# Altium schematic sheet Weapon_of_Aphelios.SchDoc
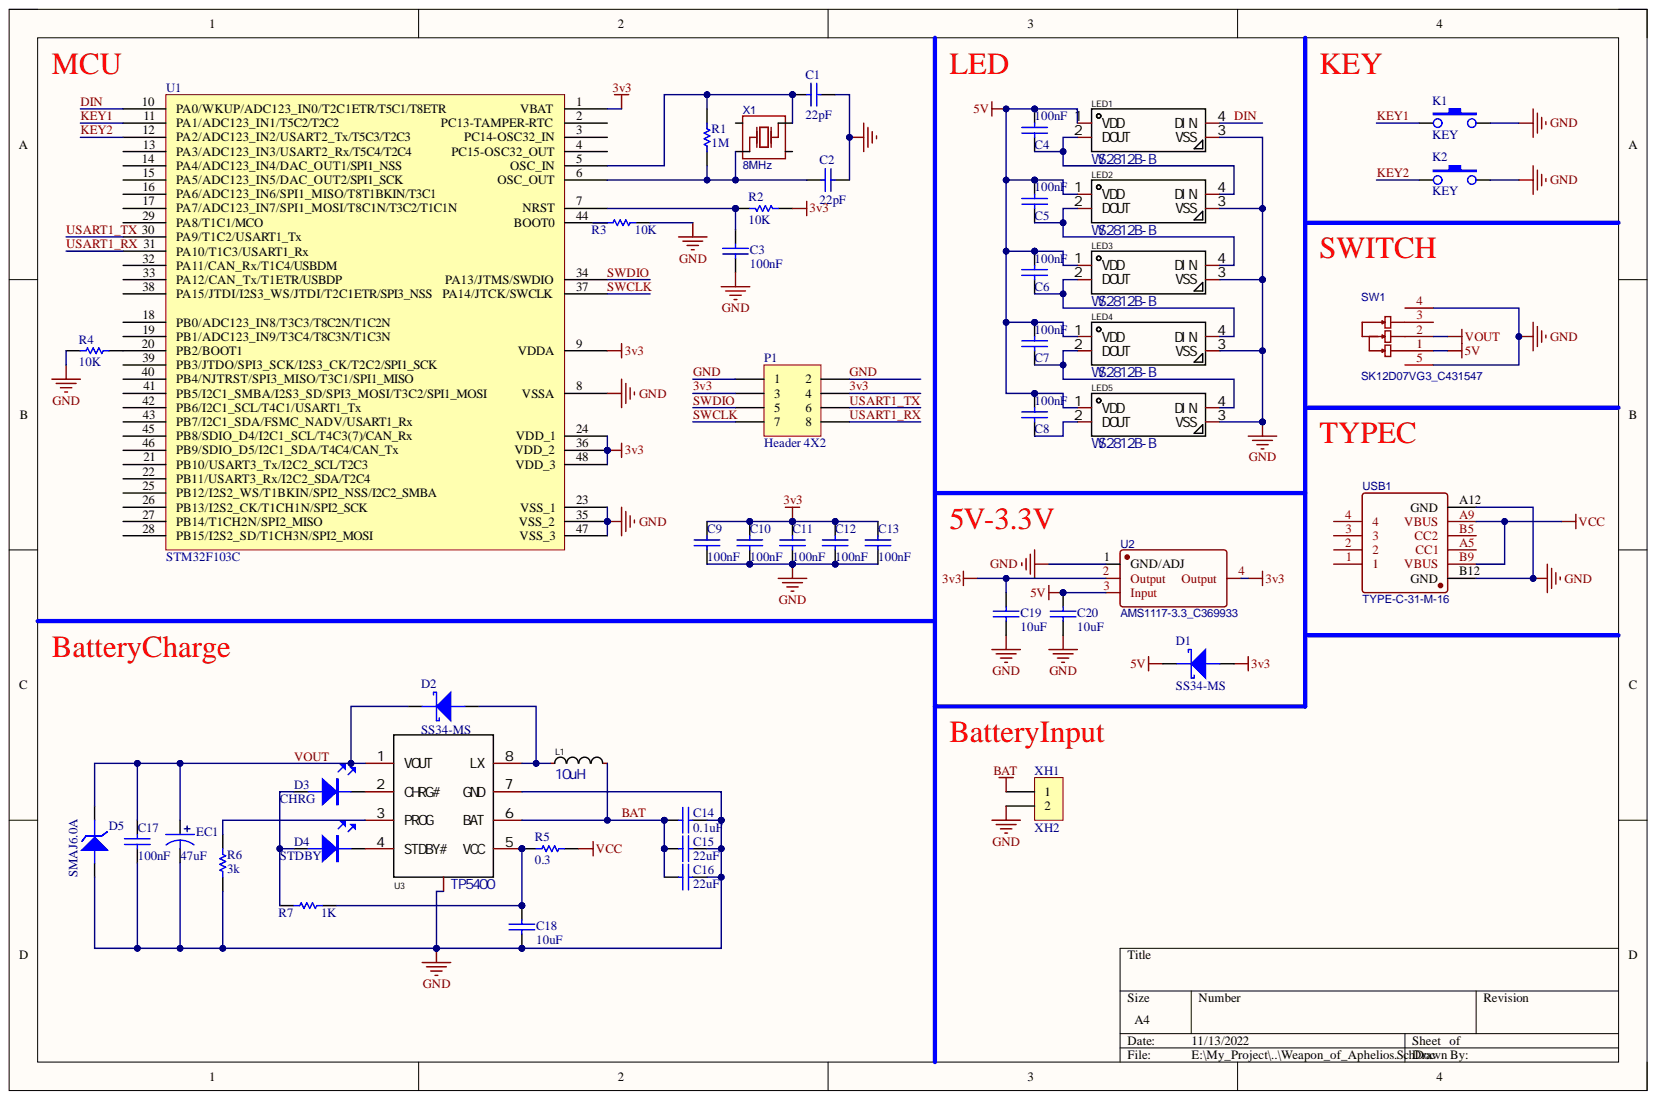
[diagram p0: Weapon_of_Aphelios.SchDoc]

## Parts
C1  22pF  [0603C]
C2  22pF  [0603C]
C3  100nF  [0603C]
C4  100nF  [0603C]
C5  100nF  [0603C]
C6  100nF  [0603C]
C7  100nF  [0603C]
C8  100nF  [0603C]
C9  100nF  [0603C]
C10  100nF  [0603C]
C11  100nF  [0603C]
C12  100nF  [0603C]
C13  100nF  [0603C]
C14  0.1uF  [0603C]
C15  22uF  [0603C]
C16  22uF  [0603C]
C17  100nF  [0603C]
C18  10uF  [0603C]
C19  10uF  [0603C]
C20  10uF  [0603C]
D1  SS34-MS  [SMA/DO-214AC]
D2  SS34-MS  [SMA/DO-214AC]
D3  CHRG  [LED-0603B]
D4  STDBY  [LED-0603B]
D5  SMAJ6.0A  [SMA/DO-214AC]
EC1  47uF  [SMD-EC5.0X5.3X5.4]
K1  KEY  [SMD-6X6X6]
K2  KEY  [SMD-6X6X6]
L1  10uH  [IND-SMD_L4.5-W4.1_TMPA0402SP]
LED1  WS2812B-B  [LED-SMD_4P-L5.0-W5.0-TL_WS2812B-B]
LED2  WS2812B-B  [LED-SMD_4P-L5.0-W5.0-TL_WS2812B-B]
LED3  WS2812B-B  [LED-SMD_4P-L5.0-W5.0-TL_WS2812B-B]
LED4  WS2812B-B  [LED-SMD_4P-L5.0-W5.0-TL_WS2812B-B]
LED5  WS2812B-B  [LED-SMD_4P-L5.0-W5.0-TL_WS2812B-B]
P1  Header 4X2  [HDR2X4]
R1  1M  [0603R]
R2  10K  [0603R]
R3  10K  [0603R]
R4  10K  [0603R]
R5  0.3  [0603R]
R6  3k  [0603R]
R7  1K  [0603R]
SW1  SK12D07VG3_C431547  [SW-TH_SK12D07VG3]
U1  STM32F103C  [LQFP-48_M]
U2  AMS1117-3.3_C369933  [SOT-223-4_L6.5-W3.5-P2.30-LS7.0-BR]
U3  TP5400  [SOIC-8_L5.0-W4.0-P1.27-LS6.0-BL-EP2.0]
USB1  TYPE-C-31-M-16  [TYPE-C-31-M-16]
X1  8MHz  [OSC-SMD_4P-L3.2-W2.5-BL]
XH1  XH2  [XH2/2.54mm/90]

## Nets
5V: C4.2 C5.2 C6.2 C7.2 C8.2 C20.2 D1.K LED1.1(VDD) LED2.1(VDD) LED3.1(VDD) LED4.1(VDD) LED5.1(VDD) SW1.1 U2.3(Input)
VCC: R5.1 USB1.A9(VBUS) USB1.B9(VBUS)
3v3: C9.2 C10.2 C11.2 C12.2 C13.2 C19.2 D1.A P1.3 P1.4 R2.1 U1.1(VBAT) U1.9(VDDA) U1.24(VDD_1) U1.36(VDD_2) U1.48(VDD_3) U2.2(Output) U2.4(Output)
BAT: C14.1 C15.1 C16.1 L1.2 U3.6(BAT) XH1.1
DIN: LED1.4(DIN) U1.10(PA0/WKUP/ADC123_IN0/T2C1ETR/T5C1/T8ETR)
GND: C1.1 C2.1 C3.2 C9.1 C10.1 C11.1 C12.1 C13.1 C14.2 C15.2 C16.2 C17.1 C18.1 C19.1 C20.1 D5.A EC1.2 K1.3 K2.3 LED1.3(VSS) LED2.3(VSS) LED3.3(VSS) LED4.3(VSS) LED5.3(VSS) P1.1 P1.2 R3.1 R4.1 R6.2 SW1.4 SW1.5 U1.8(VSSA) U1.23(VSS_1) U1.35(VSS_2) U1.47(VSS_3) U2.1(GND/ADJ) U3.7(GND) U3.9 USB1.A12(GND) USB1.B12(GND) XH1.2
KEY1: K1.1 U1.11(PA1/ADC123_IN1/T5C2/T2C2)
KEY2: K2.1 U1.12(PA2/ADC123_IN2/USART2_Tx/T5C3/T2C3)
SWCLK: P1.7 U1.37(PA14/JTCK/SWCLK)
SWDIO: P1.5 U1.34(PA13/JTMS/SWDIO)
USART1_RX: P1.8 U1.31(PA10/T1C3/USART1_Rx)
USART1_TX: P1.6 U1.30(PA9/T1C2/USART1_Tx)
VOUT: C17.2 D2.K D5.K EC1.1 SW1.2 U3.1(VOUT)
NetC1_2: C1.2 R1.1 U1.5(OSC_IN) X1.3
NetC2_2: C2.2 R1.2 U1.6(OSC_OUT) X1.1
NetC3_1: C3.1 R2.2 U1.7(NRST)
NetC4_1: C4.1 LED1.2(DOUT) LED2.4(DIN)
NetC5_1: C5.1 LED2.2(DOUT) LED3.4(DIN)
NetC6_1: C6.1 LED3.2(DOUT) LED4.4(DIN)
NetC7_1: C7.1 LED4.2(DOUT) LED5.4(DIN)
NetC8_1: C8.1 LED5.2(DOUT)
NetC18_2: C18.2 R5.2 R7.1 U3.5(VCC)
NetD2_A: D2.A L1.1 U3.8(LX)
NetD3_A: D3.A D4.A R7.2
NetD3_K: D3.K U3.2(CHRG#)
NetD4_K: D4.K U3.4(STDBY#)
NetR3_2: R3.2 U1.44(BOOT0)
NetR4_2: R4.2 U1.20(PB2/BOOT1)
NetR6_1: R6.1 U3.3(PROG)

Not connected: SW1 3; U1 2(PC13-TAMPER-RTC) 3(PC14-OSC32_IN) 4(PC15-OSC32_OUT) 13(PA3/ADC123_IN3/USART2_Rx/T5C4/T2C4) 14(PA4/ADC123_IN4/DAC_OUT1/SPI1_NSS) 15(PA5/ADC123_IN5/DAC_OUT2/SPI1_SCK) 16(PA6/ADC123_IN6/SPI1_MISO/T8T1BKIN/T3C1) 17(PA7/ADC123_IN7/SPI1_MOSI/T8C1N/T3C2/T1C1N) 18(PB0/ADC123_IN8/T3C3/T8C2N/T1C2N) 19(PB1/ADC123_IN9/T3C4/T8C3N/T1C3N) 21(PB10/USART3_Tx/I2C2_SCL/T2C3) 22(PB11/USART3_Rx/I2C2_SDA/T2C4) 25(PB12/I2S2_WS/T1BKIN/SPI2_NSS/I2C2_SMBA) 26(PB13/I2S2_CK/T1CH1N/SPI2_SCK) 27(PB14/T1CH2N/SPI2_MISO) 28(PB15/I2S2_SD/T1CH3N/SPI2_MOSI) 29(PA8/T1C1/MCO) 32(PA11/CAN_Rx/T1C4/USBDM) 33(PA12/CAN_Tx/T1ETR/USBDP) 38(PA15/JTDI/I2S3_WS/JTDI/T2C1ETR/SPI3_NSS) 39(PB3/JTDO/SPI3_SCK/I2S3_CK/T2C2/SPI1_SCK) 40(PB4/NJTRST/SPI3_MISO/T3C1/SPI1_MISO) 41(PB5/I2C1_SMBA/I2S3_SD/SPI3_MOSI/T3C2/SPI1_MOSI) 42(PB6/I2C1_SCL/T4C1/USART1_Tx) 43(PB7/I2C1_SDA/FSMC_NADV/USART1_Rx) 45(PB8/SDIO_D4/I2C1_SCL/T4C3(7)/CAN_Rx) 46(PB9/SDIO_D5/I2C1_SDA/T4C4/CAN_Tx); USB1 1 2 3 4 A5(CC1) B5(CC2); X1 2 4

## Library data of the parts
C1 C2 = CAP: Component Kind: Standard; Library Name: 转角传感器200823.SchLib
C3-C20 = CAP: 无极性电容; Component Kind: Standard; Library Name: 电容.IntLib
D1 D2 = DIODE SCHOTTKY: 肖特基二极管; Component Kind: Standard; Library Name: 二极管.IntLib
D3 D4 = LED: Component Kind: Standard; Library Name: LED.IntLib
D5 = DIODE ZENER: 瞬态二极管 SMAJ6.0A DO-214AC 6.0V; Component Kind: Standard; Library Name: 二极管.IntLib
EC1 = SMD_ECAP: 贴片电解电容; Component Kind: Standard; Library Name: 电容.IntLib
L1 = TMPC0402HP-100MG-Z02: Manufacturer: 台庆; Add into BOM: yes; DC Resistance (DCR): 215mΩ; Rated Current: 1.4A; Tolerance: ±20%; Component Kind: Standard; Convert to PCB: yes; Datasheet: https://atta.szlcsc.com/upload/public/pdf/source/20200917/C305203_F071C71654DE86DE842DF29DC4BB6A78.pdf; Device: TMPC0402HP-100MG-Z02; JLCPCB Part Class: Extended Part; LCSC Part Name: 10uH ±20% 1.4A 215mΩ; Library Name: 0420_10uH.IntLib; Manufacturer Part: TMPC0402HP-100MG-Z02; Name: 10uH; Supplier: LCSC; Supplier Footprint: SMD; Supplier Part: C305203; Symbol: TMPC0402HP-100MG-Z02; Value: 10uH
LED1-LED5 = WS2812B-B: Manufacturer: worldsemi; Add into BOM: yes; Emitted Color: RGB三色; Component Kind: Standard; Convert to PCB: yes; Datasheet: https://atta.szlcsc.com/upload/public/pdf/source/20200615/C114586_26EB6813EC9F2A3F6C7FBB2AD75DE01F.pdf; Device: WS2812B-B; JLCPCB Part Class: Extended Part; LCSC Part Name: RGB三色 （注意防潮，请查阅规格书中对应具体内容）; Library Name: WS2812B.IntLib; Manufacturer Part: WS2812B-B; Name: WS2812B-B; Supplier: LCSC; Supplier Footprint: 5050(mm); Supplier Part: C114586; Symbol: WS2812B-B
P1 = Header 4X2: Header, 4-Pin, Dual row; Component Kind: Standard; LatestRevisionDate: 17-Jul-2002; LatestRevisionNote: Re-released for DXP Platform.; Library Name: Miscellaneous Connectors.IntLib; Publisher: Altium Limited; Signal Integrity: Connector
R1-R7 = RES: Component Kind: Standard; Library Name: 电阻.IntLib
SW1 = SK12D07VG3_C431547: BOM_Manufacturer: SHOU HAN; Contributor: LCSC; BOM_JLCPCB Part Class: Extended Part; BOM_Manufacturer Part: SK12D07VG3; BOM_Supplier: LCSC; BOM_Supplier Part: C431547; Component Kind: Standard; Library Name: SK12D07VG3.IntLib; spicePre: S; spiceSymbolName: SK12D07VG3_C431547
U1 = STM32F103C: STM32单片机48引脚; Component Kind: Standard; Library Name: Fszhang.IntLib
U2 = AMS1117-3.3_C369933: BOM_Manufacturer: KEXIN; BOM_Assembly Type: smtWeld; BOM_Paste Type: expand; Contributor: LCSC; BOM_Manufacturer Part: AMS1117-3.3; BOM_Supplier: LCSC; BOM_Supplier Part: C369933; Component Kind: Standard; Library Name: AMS1117-3.3.IntLib; spicePre: U; spiceSymbolName: AMS1117-3.3_C369933
U3 = TP5400: Manufacturer: TOPPOWER(南京拓微); Add into BOM: yes; Battery Chemistry: -; Battery Pack Voltage: -; Charge Current - Max: -; Component Kind: Standard; Convert to PCB: yes; Datasheet: https://atta.szlcsc.com/upload/public/pdf/source/20131206/1457706662490.pdf; Device: TP5400; Interface: -; JLCPCB Part Class: Extended Part; LCSC Part Name: TP5400; Library Name: TP5400.IntLib; Manufacturer Part: TP5400; Name: TP5400; Number of Cells: -; Supplier: LCSC; Supplier Footprint: SOIC-8_EP_150mil; Supplier Part: C24154; Symbol: TP5400; Voltage - Supply (Max): -
X1 = 8MHz 10pF ±10ppm: BOM_Manufacturer: Zhejiang Abel Elec; BOM_Manufacturer Part: TAXM8M4RDBCCT2T; BOM_Assembly Type: smtWeld; BOM_Paste Type: expand; Contributor: LCSC; nameAlias: Frequency; BOM_Supplier: LCSC; BOM_Supplier Part: C400090; Component Kind: Standard; Library Name: 4G_wireless.IntLib; spicePre: X; spiceSymbolName: TAXM8M4RDBCCT2T
K1 K2 = KEY: Component Kind: Standard; Library Name: 轻触开关.IntLib
USB1 = TYPE-C-31-M-16: Component Kind: Standard; Library Name: type-c.IntLib
XH1 = XH2: Component Kind: Standard; Library Name: XH，VH.IntLib
